# Altium schematic sheet Peryferia.SchDoc
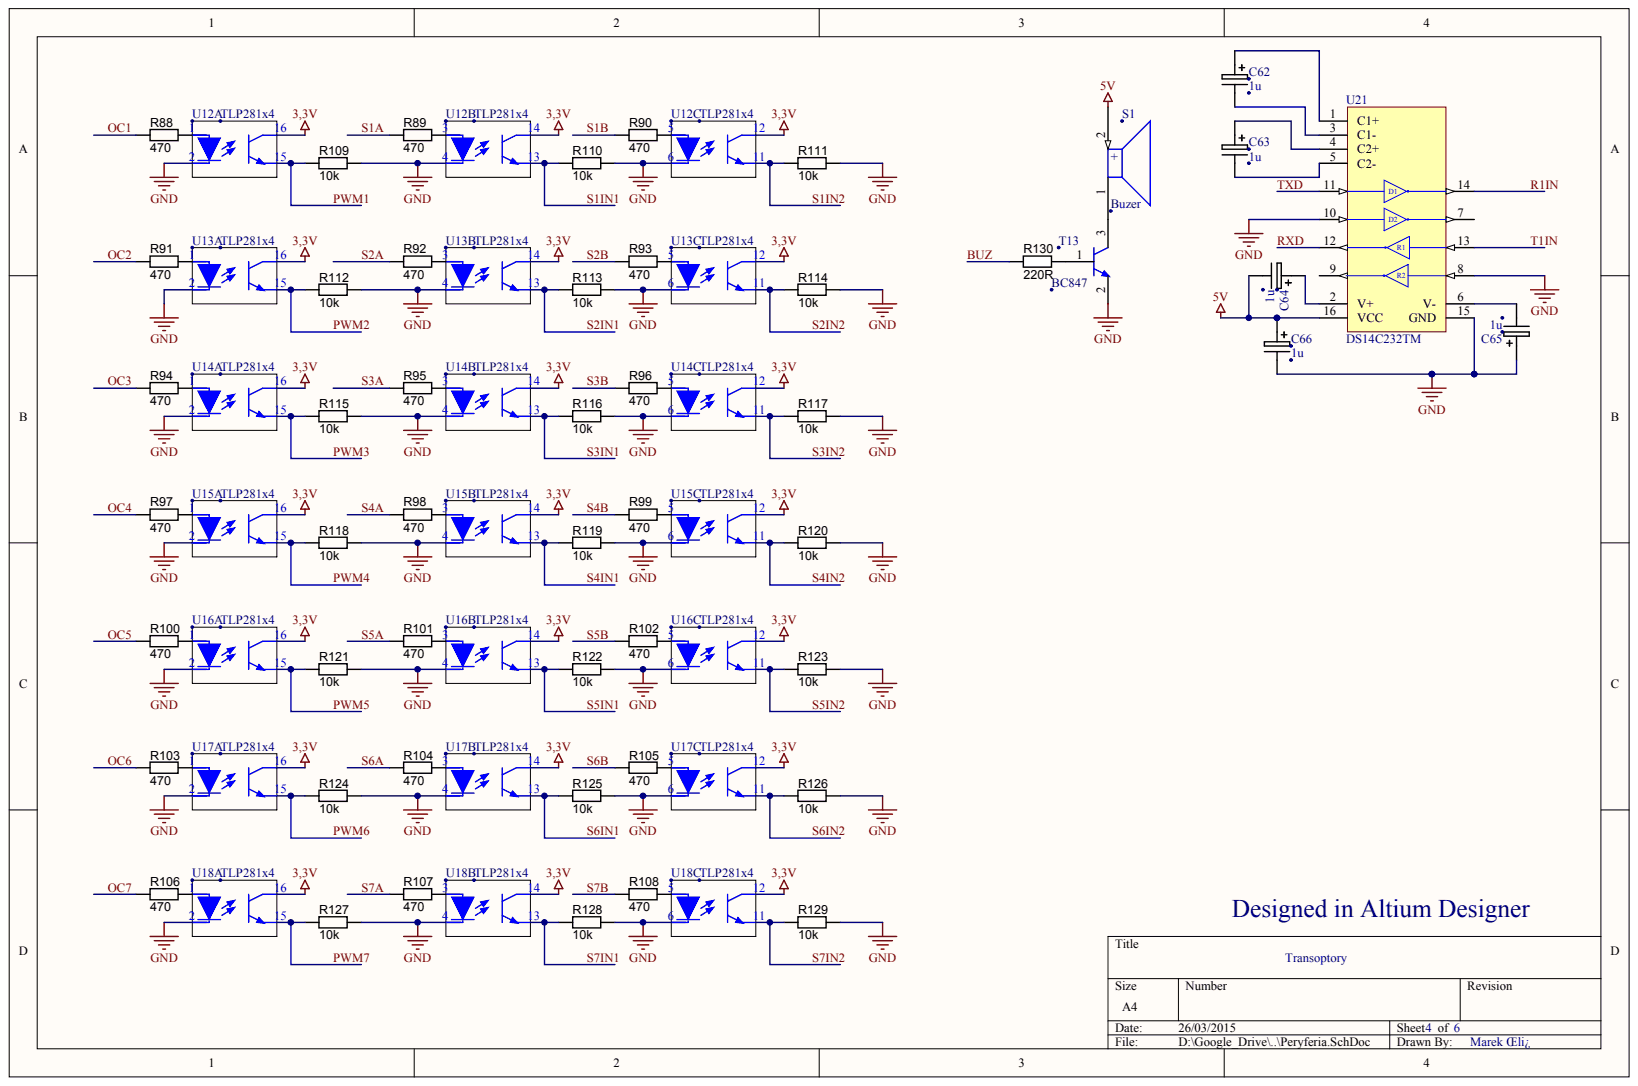
[diagram p0: Peryferia.SchDoc]

## Parts
C62  1u
C63  1u
C64  1u
C65  1u
C66  1u
R88  value 470  (hidden comment: RES_NL_0805)
R89  value 470  (hidden comment: RES_NL_0805)
R90  value 470  (hidden comment: RES_NL_0805)
R91  value 470  (hidden comment: RES_NL_0805)
R92  value 470  (hidden comment: RES_NL_0805)
R93  value 470  (hidden comment: RES_NL_0805)
R94  value 470  (hidden comment: RES_NL_0805)
R95  value 470  (hidden comment: RES_NL_0805)
R96  value 470  (hidden comment: RES_NL_0805)
R97  value 470  (hidden comment: RES_NL_0805)
R98  value 470  (hidden comment: RES_NL_0805)
R99  value 470  (hidden comment: RES_NL_0805)
R100  value 470  (hidden comment: RES_NL_0805)
R101  value 470  (hidden comment: RES_NL_0805)
R102  value 470  (hidden comment: RES_NL_0805)
R103  value 470  (hidden comment: RES_NL_0805)
R104  value 470  (hidden comment: RES_NL_0805)
R105  value 470  (hidden comment: RES_NL_0805)
R106  value 470  (hidden comment: RES_NL_0805)
R107  value 470  (hidden comment: RES_NL_0805)
R108  value 470  (hidden comment: RES_NL_0805)
R109  value 10k  (hidden comment: RES_NL_0805)
R110  value 10k  (hidden comment: RES_NL_0805)
R111  value 10k  (hidden comment: RES_NL_0805)
R112  value 10k  (hidden comment: RES_NL_0805)
R113  value 10k  (hidden comment: RES_NL_0805)
R114  value 10k  (hidden comment: RES_NL_0805)
R115  value 10k  (hidden comment: RES_NL_0805)
R116  value 10k  (hidden comment: RES_NL_0805)
R117  value 10k  (hidden comment: RES_NL_0805)
R118  value 10k  (hidden comment: RES_NL_0805)
R119  value 10k  (hidden comment: RES_NL_0805)
R120  value 10k  (hidden comment: RES_NL_0805)
R121  value 10k  (hidden comment: RES_NL_0805)
R122  value 10k  (hidden comment: RES_NL_0805)
R123  value 10k  (hidden comment: RES_NL_0805)
R124  value 10k  (hidden comment: RES_NL_0805)
R125  value 10k  (hidden comment: RES_NL_0805)
R126  value 10k  (hidden comment: RES_NL_0805)
R127  value 10k  (hidden comment: RES_NL_0805)
R128  value 10k  (hidden comment: RES_NL_0805)
R129  value 10k  (hidden comment: RES_NL_0805)
R130  value 220R  (hidden comment: Thick Film)
S1  Buzer
T13  BC847
U12  TLP281x4  (parts A,B,C)
U13  TLP281x4  (parts A,B,C)
U14  TLP281x4  (parts A,B,C)
U15  TLP281x4  (parts A,B,C)
U16  TLP281x4  (parts A,B,C)
U17  TLP281x4  (parts A,B,C)
U18  TLP281x4  (parts A,B,C)
U21  DS14C232TM

## Nets
3,3V: U12.12 U12.14 U12.16 U13.12 U13.14 U13.16 U14.12 U14.14 U14.16 U15.12 U15.14 U15.16 U16.12 U16.14 U16.16 U17.12 U17.14 U17.16 U18.12 U18.14 U18.16
5V: C64.2 C66.1 S1.2 U21.16(VCC)
GND: C65.1 C66.2 R109.2 R110.2 R111.2 R112.2 R113.2 R114.2 R115.2 R116.2 R117.2 R118.2 R119.2 R120.2 R121.2 R122.2 R123.2 R124.2 R125.2 R126.2 R127.2 R128.2 R129.2 T13.2 U12.2 U12.4 U12.6 U13.2 U13.4 U13.6 U14.2 U14.4 U14.6 U15.2 U15.4 U15.6 U16.2 U16.4 U16.6 U17.2 U17.4 U17.6 U18.2 U18.4 U18.6 U21.8 U21.10 U21.15(GND)
BUZ: R130.1
OC1: R88.1
OC2: R91.1
OC3: R94.1
OC4: R97.1
OC5: R100.1
OC6: R103.1
OC7: R106.1
PWM1: R109.1 U12.15
PWM2: R112.1 U13.15
PWM3: R115.1 U14.15
PWM4: R118.1 U15.15
PWM5: R121.1 U16.15
PWM6: R124.1 U17.15
PWM7: R127.1 U18.15
R1IN: U21.14
RXD: U21.12
S1A: R89.1
S1B: R90.1
S1IN1: R110.1 U12.13
S1IN2: R111.1 U12.11
S2A: R92.1
S2B: R93.1
S2IN1: R113.1 U13.13
S2IN2: R114.1 U13.11
S3A: R95.1
S3B: R96.1
S3IN1: R116.1 U14.13
S3IN2: R117.1 U14.11
S4A: R98.1
S4B: R99.1
S4IN1: R119.1 U15.13
S4IN2: R120.1 U15.11
S5A: R101.1
S5B: R102.1
S5IN1: R122.1 U16.13
S5IN2: R123.1 U16.11
S6A: R104.1
S6B: R105.1
S6IN1: R125.1 U17.13
S6IN2: R126.1 U17.11
S7A: R107.1
S7B: R108.1
S7IN1: R128.1 U18.13
S7IN2: R129.1 U18.11
T1IN: U21.13
TXD: U21.11
NetC62_1: C62.1 U21.1(C1+)
NetC62_2: C62.2 U21.3(C1-)
NetC63_1: C63.1 U21.4(C2+)
NetC63_2: C63.2 U21.5(C2-)
NetC64_1: C64.1 U21.2(V+)
NetC65_2: C65.2 U21.6(V-)
NetR88_2: R88.2 U12.1
NetR89_2: R89.2 U12.3
NetR90_2: R90.2 U12.5
NetR91_2: R91.2 U13.1
NetR92_2: R92.2 U13.3
NetR93_2: R93.2 U13.5
NetR94_2: R94.2 U14.1
NetR95_2: R95.2 U14.3
NetR96_2: R96.2 U14.5
NetR97_2: R97.2 U15.1
NetR98_2: R98.2 U15.3
NetR99_2: R99.2 U15.5
NetR100_2: R100.2 U16.1
NetR101_2: R101.2 U16.3
NetR102_2: R102.2 U16.5
NetR103_2: R103.2 U17.1
NetR104_2: R104.2 U17.3
NetR105_2: R105.2 U17.5
NetR106_2: R106.2 U18.1
NetR107_2: R107.2 U18.3
NetR108_2: R108.2 U18.5
NetR130_2: R130.2 T13.1
NetS1_1: S1.1 T13.3

Not connected: U21 7 9

## Library data of the parts
R88-R129 = (no symbol name): Manufacturer 1: Royal Ohm; Ratings: 1/10 W; Tolerance: ±5%; Package / Case: 0805 (2012 metric)
R130 = (no symbol name): Manufacturer 1: Rohm Semiconductor; Manufacturer Part Number 1: MCR10EZPJ000; Tolerance: ±5%; Ratings: 1/8W; Package / Case: 0805 (2012 metric)
U21 = (no symbol name): Manufacturer: National Semiconductor; PackageTopMarking: NSUZXYTTE#*DS14C232TM; PowerWise: N; CompType: Interface IC; High-Reliability: Y; DatasheetVersion: Oct-1999; PackageVersion: revJ, Aug-1995; PackageReference: M16A; PackageDescription: Molded Package, SO, .150in Wide, 16 Lead; PartNumber: DS14C232TM; RoHS: N; PinCount: 16; Code_JEDEC: MS-012-AC; Code_IPC: SOIC127P600-16; ComponentLink1Description: Manufacturer URL; ComponentLink1URL: http://www.national.com/; ComponentLink2Description: DS14C232 Datasheet; ComponentLink2URL: http://www.national.com/ds/DS/DS14C232.pdf; ComponentLink3Description: DS14C232 Web Page; ComponentLink3URL: http://www.national.com/pf/DS/DS14C232.html; ComponentLink4Description: M16A Package Page; ComponentLink4URL: http://www.national.com/packaging/folders/m16a.html
C62-C66 S1 T13 U12-U18 = (no symbol name)
  C62-C66: Value: 1u
